# Altium schematic sheet 1_MCU.SchDoc
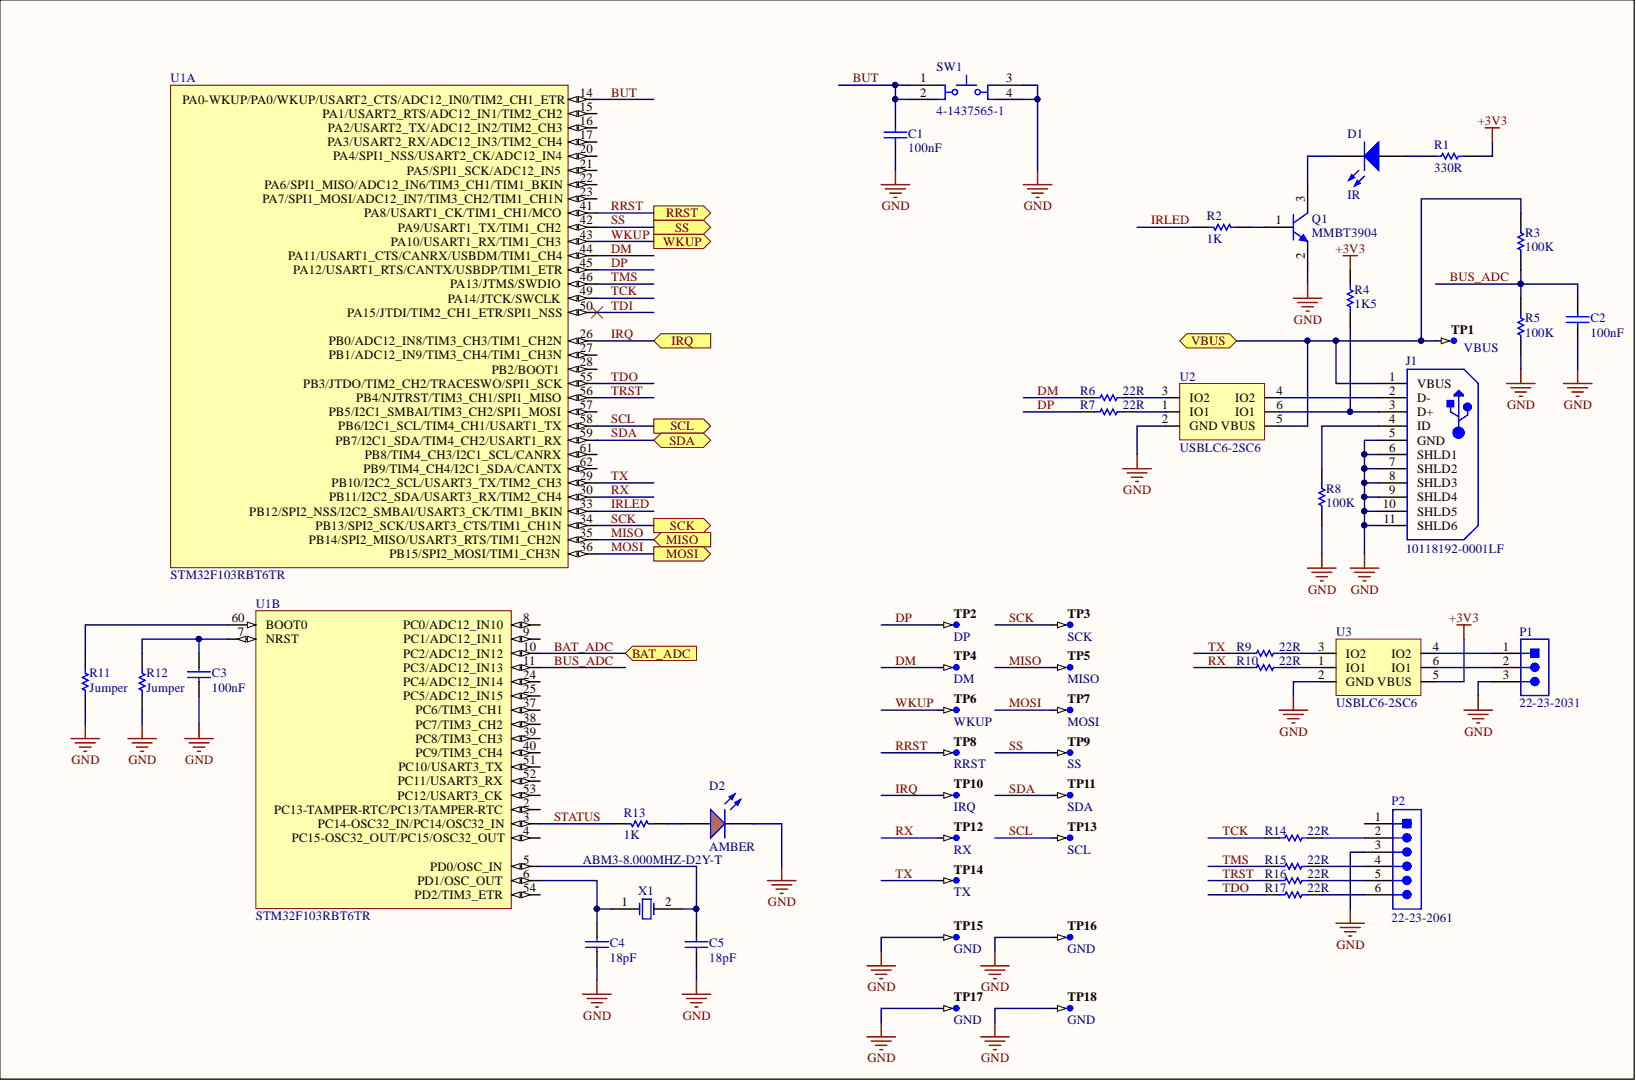
[diagram p0: 1_MCU.SchDoc]

## Parts
C1  100nF  [CAPC0603(1608)100_M]
C2  100nF  [CAPC0603(1608)100_M]
C3  100nF  [CAPC0603(1608)100_M]
C4  18pF  [CAPC0603(1608)100_M]
C5  18pF  [CAPC0603(1608)100_M]
D1  IR  [Type 3mm]
D2  AMBER  [LTST-C171AKT_V]
J1  10118192-0001LF  [FCI-10118192-0001LF-5_V]
P1  22-23-2031  [MOLX-22-23-2031_V]
P2  22-23-2061  [MOLX-22-23-2061_V]
Q1  MMBT3904  [FAIR-SOT23-3_V]
R1  330R  [RESC0603(1608)_M]
R2  1K  [RESC0603(1608)_M]
R3  100K  [RESC0603(1608)_M]
R4  1K5  [RESC0603(1608)_M]
R5  100K  [RESC0603(1608)_M]
R6  22R  [RESC0603(1608)_M]
R7  22R  [RESC0603(1608)_M]
R8  100K  [RESC0603(1608)_M]
R9  22R  [RESC0603(1608)_M]
R10  22R  [RESC0603(1608)_M]
R11  Jumper  [RESC0805(2012)_M]
R12  Jumper  [RESC0805(2012)_M]
R13  1K  [RESC0603(1608)_M]
R14  22R  [RESC0603(1608)_M]
R15  22R  [RESC0603(1608)_M]
R16  22R  [RESC0603(1608)_M]
R17  22R  [RESC0603(1608)_M]
SW1  4-1437565-1  [TECO-4-1437565-1_V]
TP1  VBUS  [TP-SM-C-100]  (no BOM)
TP2  DP  [TP-SM-C-100]  (no BOM)
TP3  SCK  [TP-SM-C-100]  (no BOM)
TP4  DM  [TP-SM-C-100]  (no BOM)
TP5  MISO  [TP-SM-C-100]  (no BOM)
TP6  WKUP  [TP-SM-C-100]  (no BOM)
TP7  MOSI  [TP-SM-C-100]  (no BOM)
TP8  RRST  [TP-SM-C-100]  (no BOM)
TP9  SS  [TP-SM-C-100]  (no BOM)
TP10  IRQ  [TP-SM-C-100]  (no BOM)
TP11  SDA  [TP-SM-C-100]  (no BOM)
TP12  RX  [TP-SM-C-100]  (no BOM)
TP13  SCL  [TP-SM-C-100]  (no BOM)
TP14  TX  [TP-SM-C-100]  (no BOM)
TP15  GND  [TP-SM-C-100]  (no BOM)
TP16  GND  [TP-SM-C-100]  (no BOM)
TP17  GND  [TP-SM-C-100]  (no BOM)
TP18  GND  [TP-SM-C-100]  (no BOM)
U1  STM32F103RBT6TR  [STM-LQFP64_N]  (parts A,B)
U2  USBLC6-2SC6  [SOT95P280X145-6N]
U3  USBLC6-2SC6  [SOT95P280X145-6N]
X1  ABM3-8.000MHZ-D2Y-T  [ABRA-ABM3-2_V]

## Ports
BAT_ADC, IRQ, MISO, MOSI, RRST, SCK, SCL, SDA, SS, VBUS, WKUP

## Nets
+3V3: R1.2 R4.2 U3.5(VBUS)
GND: C1.2 C2.2 C3.2 C4.1 C5.1 D2.C J1.5(GND) J1.6(SHLD1) J1.7(SHLD2) J1.8(SHLD3) J1.9(SHLD4) J1.10(SHLD5) J1.11(SHLD6) P1.3 P2.3 Q1.2 R5.1 R8.1 R11.1 R12.1 SW1.3 SW1.4 TP15.1 TP16.1 TP17.1 TP18.1 U2.2(GND) U3.2(GND)
BAT_ADC: U1.10(PC2/ADC12_IN12) ; port BAT_ADC
BUS_ADC: C2.1 R3.1 R5.2 U1.11(PC3/ADC12_IN13)
BUT: C1.1 SW1.1 SW1.2 U1.14(PA0-WKUP/PA0/WKUP/USART2_CTS/ADC12_IN0/TIM2_CH1_ETR)
DM: R6.1 TP4.1 U1.44(PA11/USART1_CTS/CANRX/USBDM/TIM1_CH4)
DP: R7.1 TP2.1 U1.45(PA12/USART1_RTS/CANTX/USBDP/TIM1_ETR)
IRLED: R2.2 U1.33(PB12/SPI2_NSS/I2C2_SMBAl/USART3_CK/TIM1_BKIN)
IRQ: TP10.1 U1.26(PB0/ADC12_IN8/TIM3_CH3/TIM1_CH2N) ; port IRQ
MISO: TP5.1 U1.35(PB14/SPI2_MISO/USART3_RTS/TIM1_CH2N) ; port MISO
MOSI: TP7.1 U1.36(PB15/SPI2_MOSI/TIM1_CH3N) ; port MOSI
RRST: TP8.1 U1.41(PA8/USART1_CK/TIM1_CH1/MCO) ; port RRST
RX: R10.1 TP12.1 U1.30(PB11/I2C2_SDA/USART3_RX/TIM2_CH4)
SCK: TP3.1 U1.34(PB13/SPI2_SCK/USART3_CTS/TIM1_CH1N) ; port SCK
SCL: TP13.1 U1.58(PB6/I2C1_SCL/TIM4_CH1/USART1_TX) ; port SCL
SDA: TP11.1 U1.59(PB7/I2C1_SDA/TIM4_CH2/USART1_RX) ; port SDA
SS: TP9.1 U1.42(PA9/USART1_TX/TIM1_CH2) ; port SS
STATUS: R13.2 U1.3(PC14-OSC32_IN/PC14/OSC32_IN)
TCK: R14.1 U1.49(PA14/JTCK/SWCLK)
TDI: U1.50(PA15/JTDI/TIM2_CH1_ETR/SPI1_NSS)
TDO: R17.1 U1.55(PB3/JTDO/TIM2_CH2/TRACESWO/SPI1_SCK)
TMS: R15.1 U1.46(PA13/JTMS/SWDIO)
TRST: R16.1 U1.56(PB4/NJTRST/TIM3_CH1/SPI1_MISO)
TX: R9.1 TP14.1 U1.29(PB10/I2C2_SCL/USART3_TX/TIM2_CH3)
VBUS: J1.1(VBUS) R3.2 TP1.1 U2.5(VBUS) ; port VBUS
WKUP: TP6.1 U1.43(PA10/USART1_RX/TIM1_CH3) ; port WKUP
NetC3_1: C3.1 R12.2 U1.7(NRST)
NetC4_2: C4.2 U1.6(PD1/OSC_OUT) X1.1
NetC5_2: C5.2 U1.5(PD0/OSC_IN) X1.2
NetD1_1: D1.1 Q1.3
NetD1_2: D1.2 R1.1
NetD2_A: D2.A R13.1
NetJ1_2: J1.2(D-) U2.4(IO2)
NetJ1_3: J1.3(D+) R4.1 U2.6(IO1)
NetJ1_4: J1.4(ID) R8.2
NetP1_1: P1.1 U3.4(IO2)
NetP1_2: P1.2 U3.6(IO1)
NetP2_2: P2.2 R14.2
NetP2_4: P2.4 R15.2
NetP2_5: P2.5 R16.2
NetP2_6: P2.6 R17.2
NetQ1_1: Q1.1 R2.1
NetR6_2: R6.2 U2.3(IO2)
NetR7_2: R7.2 U2.1(IO1)
NetR9_2: R9.2 U3.3(IO2)
NetR10_2: R10.2 U3.1(IO1)
NetR11_2: R11.2 U1.60(BOOT0)

Not connected: P2 1; U1 2(PC13-TAMPER-RTC/PC13/TAMPER-RTC) 4(PC15-OSC32_OUT/PC15/OSC32_OUT) 8(PC0/ADC12_IN10) 9(PC1/ADC12_IN11) 15(PA1/USART2_RTS/ADC12_IN1/TIM2_CH2) 16(PA2/USART2_TX/ADC12_IN2/TIM2_CH3) 17(PA3/USART2_RX/ADC12_IN3/TIM2_CH4) 20(PA4/SPI1_NSS/USART2_CK/ADC12_IN4) 21(PA5/SPI1_SCK/ADC12_IN5) 22(PA6/SPI1_MISO/ADC12_IN6/TIM3_CH1/TIM1_BKIN) 23(PA7/SPI1_MOSI/ADC12_IN7/TIM3_CH2/TIM1_CH1N) 24(PC4/ADC12_IN14) 25(PC5/ADC12_IN15) 27(PB1/ADC12_IN9/TIM3_CH4/TIM1_CH3N) 28(PB2/BOOT1) 37(PC6/TIM3_CH1) 38(PC7/TIM3_CH2) 39(PC8/TIM3_CH3) 40(PC9/TIM3_CH4) 51(PC10/USART3_TX) 52(PC11/USART3_RX) 53(PC12/USART3_CK) 54(PD2/TIM3_ETR) 57(PB5/I2C1_SMBAI/TIM3_CH2/SPI1_MOSI) 61(PB8/TIM4_CH3/I2C1_SCL/CANRX) 62(PB9/TIM4_CH4/I2C1_SDA/CANTX)

## Library data of the parts
C1-C3 = CMP-1035-02589-1: CAP 100nF 25V ±20% 0603 (1608 Metric) Thickness 1mm SMD; Case-EIA: 0603; Case-Metric: 1608; Tolerance: Â±20%; Component Kind: Standard; Library Name: Altium Content Vault; Max Thickness: 1 mm; Rated Voltage: 25 V; Technology: SMT; Value: 100nF
C4 C5 = CMP-1035-00741-1: CAP 18pF 25V ±0.5pF 0603 (1608 Metric) Thickness 1mm SMD; Case-EIA: 0603; Case-Metric: 1608; Tolerance: Â±0.5pF; Component Kind: Standard; Library Name: Altium Content Vault; Max Thickness: 1 mm; Rated Voltage: 25 V; Technology: SMT; Value: 18pF
D1 = CMP-1488-00005-1: THT LED round mono-color color lens, WL-TMRW, Super Red; Manufacturer: Wuerth Elektronik; PartNumber: 151031SS04000; Component Kind: Standard; ComponentLink1Description: Manufacturer URL; ComponentLink1URL: http://www.we-online.com; ComponentLink2Description: Datasheet; ComponentLink2URL: http://katalog.we-online.de/pbs/datasheet/7448042001.pdfhttp://katalog.we-online.de/pbs/datasheet/7448042001.pdfhttp://katalog.we-online.de/pbs/datasheet/7448042001.pdfhttp://katalog.we-online.de/pbs/datasheet/151031SS04000.pdf; Emitting color: Super Red; Library Name: Altium Content Vault; PackageReference: Type 3mm
D2 = CMP-1674-00004-3: LED AMBER CLR THIN 0805 SMD; Manufacturer: Lite-On; PartNumber: LTST-C171AKT; Component Kind: Standard; ComponentLink1Description: Datasheet; ComponentLink1URL: http://optoelectronics.liteon.com/upload/download/DS-22-99-0170/S_110_LTST-C171AKT.pdf; Library Name: Altium Content Vault; PackageReference: C171AKT
J1 = CMP-2000-05118-1: Micro USB B Type Receptacle, -55 to 85 degC, 5-Pin SMD, RoHS, Tape and Reel; Brand: FCI; Connector Type: MicroUSBTypeBreceptacle; Current Rating: 1.8A; Gender: Female; Insulation Resistance: 1000MOhms; Mounting Angle: Right; Number of Contacts: 5Contact; Color: Black; Component Kind: Standard; Contact Material: CopperAlloy; Contact Plating: Gold; Datasheet URL: http://www.mouser.com/ds/2/154/10118192-793027.pdf; Datasheet Version: Rev. B, 05/2015; Factory Pack Quantity: 1400; Flammability Rating: UL94V-0; Library Name: Altium Content Vault; Manufacturer: FCI; Manufacturer URL: http://www.fci.com/; Operating Temperature Range: -55Cto+85C; Packaging: Reel; Product: MicroUSBTypeBConnectors; Product Category: USBConnectors; RoHS: Details; Standard: USB2.0; Termination Style: SMD/SMT
P1 = CMP-2000-05242-1: Male Header, Pitch 2.54 mm, 1 x 3 Position, Height 8.18 mm, Tail Length 3.56 mm, RoHS, Bulk; Brand: Molex; Current Rating: 4A; Mounting Angle: Vertical; Pitch: 2.54mm; Voltage Rating: 250V; : 0022232031A-6373-03A102; Component Kind: Standard; Contact Gender: Pin(Male); Contact Material: Brass; Contact Plating: Tin; Datasheet URL: https://datasheet.ciiva.com/19472/232274-19472863.pdf; Datasheet Version: Sep-01; Factory Pack Quantity: 16000; Housing Material: Nylon; Library Name: Altium Content Vault; Manufacturer: Molex; Manufacturer URL: http://www.molex.com/; Mounting Style: ThroughHole; Number of Positions: 3Position; Number of Rows: 1Row; Packaging: Bulk; Product: Headers; Product Category: Headers&WireHousings; RoHS: Details; Series: 6373; Termination Style: ThroughHole; Tradename: KK; Type: Locking
P2 = CMP-2000-05536-1: Male Header, Pitch 2.54 mm, 1 x 6 Position, Height 10.67 mm, Tail Length 3.56 mm, 0 to 70 degC, RoHS, Bulk; Brand: Molex; Current Rating: 4A; Mounting Angle: Vertical; Number of Positions: 6; Pitch: 2.54mm; Voltage Rating: 250V; : 002223206122-23-2061-PBA-6373-06A102; Component Kind: Standard; Contact Material: Brass; Contact Plating: Tin; Datasheet URL: https://datasheet.ciiva.com/19460/232303-19460895.pdf; Datasheet Version: Rev. P, 09/2001; Factory Pack Quantity: 1000; Housing Material: Nylon; Library Name: Altium Content Vault; Manufacturer: Molex; Manufacturer URL: http://www.molex.com/; Mounting Style: ThroughHole; Mounting Technology: ThroughHole; Number of Positions / Contacts: 6; Number of Rows: 1; Package Description: Male Header, Pitch 2.54 mm, 1 x 6 Position, Height 10.67 mm, Tail Length 3.56 mm; Package Reference: 22-23-2061; Packaging: Bulk; Product Category: .100K.K.Connectors; Product Type: Headers; RoHS: Details; Series: 6373; Termination Style: ThroughHole; Tradename: KK; Type: PinStrip
Q1 = CMP-1670-00008-1: NPN General Purpose Amplifier, 40 V, 0.2 A, -55 to 150 degC, 3-Pin SOT23, RoHS, Tape and Reel; Capacitance: 1.8E-11 f; ESR: 40 Ohm; Frequency: 12.288 MHz; Frequency Tolerance: 30ppm; Load Capacitance: 18 pF; Component Kind: Standard; ComponentLink1Description: Manufacturer URL; ComponentLink1URL: https://www.fairchildsemi.com/; ComponentLink2Description: Datasheet; ComponentLink2URL: https://www.fairchildsemi.com/datasheets/MM/MMBT3904.pdf; ComponentLink3Description: Package Specification; ComponentLink3URL: http://www.fairchildsemi.com/dwg/MA/MA03D.pdf; DatasheetVersion: Rev. 1.1.0, 10/2014; Height: 0.041 inch; Length: 0.197 inch; Library Name: Altium Content Vault; Max Operating Temperature: 70degC; Min Operating Temperature: -20degC; Mount: Surface Mount; PackageDescription: 3-Pin Small Outline Transistor, Body 2.92 x 1.325 mm, Pitch 0.95 mm; Package Quantity: 1000; PackageReference: SOT23-3; Packaging: Tape and Reel; Pins: 4; REACH SVHC: No SVHC; RoHSCompliant: True; Tolerance: 30ppm; Width: 0.126 inch
R1 = CMP-1012-00454-1: 330R 0.1W 1% 0603 (1608 Metric)  SMD; Case-EIA: 0603; Case-Metric: 1608; Value: 330.00 Ohm; Component Kind: Standard; Library Name: Altium Content Vault; Power: 0.1 W; Technology: SMT; Tolerance: 1 %
R2 R13 = CMP-1012-00510-1: 1K 0.1W 1% 0603 (1608 Metric)  SMD; Case-EIA: 0603; Case-Metric: 1608; Value: 1000.00 Ohm; Component Kind: Standard; Library Name: Altium Content Vault; Power: 0.1 W; Technology: SMT; Tolerance: 1 %
R3 R5 R8 = CMP-1012-00736-1: 100K 0.1W 1% 0603 (1608 Metric)  SMD; Case-EIA: 0603; Case-Metric: 1608; Value: 100000.00 Ohm; Component Kind: Standard; Library Name: Altium Content Vault; Power: 0.1 W; Technology: SMT; Tolerance: 1 %
R4 = CMP-1012-00528-1: 1K5 0.1W 1% 0603 (1608 Metric)  SMD; Case-EIA: 0603; Case-Metric: 1608; Value: 1500.00 Ohm; Component Kind: Standard; Library Name: Altium Content Vault; Power: 0.1 W; Technology: SMT; Tolerance: 1 %
R6 R7 R9 R10 R14-R17 = CMP-1012-00320-1: 22R 0.1W 1% 0603 (1608 Metric)  SMD; Case-EIA: 0603; Case-Metric: 1608; Value: 22.00 Ohm; Component Kind: Standard; Library Name: Altium Content Vault; Power: 0.1 W; Technology: SMT; Tolerance: 1 %
R11 R12 = CMP-1013-00001-1: Jumper 0805 (2012 Metric); Case-EIA: 0805; Case-Metric: 2012; Power: None; Value: 0.00 Ohm; Component Kind: Standard; Library Name: Altium Content Vault; Technology: SMT; Tolerance: None
SW1 = CMP-2000-05602-1: Tactile Switch, 50 mA, 12 VDC, -20 to 85 degC, 4-Pin SMD, RoHS, Bulk; Manufacturer: TEConnectivity/AMPBrand; Actuator Length: 1.4mm; Actuator Style: RoundButton; Body Orientation: Straight; Contact Rating @ Voltage: 0.05@12VDCA; Dielectric Strength: 500VAC; Electrical Life: 100000Cycle; Ground Terminal: No; Illumination Type: N/R; Maximum Contact Resistance: 100mOhm; Maximum DC Voltage Rating: 12V; Operating Force: 1.57N; Actuator Color: N/A; Base Material: N/A; Component Kind: Standard; Contact Material: CopperAlloy; Contact Plating: Silver; Datasheet URL: https://datasheet.ciiva.com/14635/0900766b80912216-14635826.pdf; Datasheet Version: 04/2007; Dimension: 6x10x5.25mm; Family: FSMJSMA; Housing Material: N/A; Library Name: Altium Content Vault; Manufacturer URL: http://www.te.com/; Maximum AC Voltage Rating: N/A; Mechanical Life: N/A; Minimum Insulation Resistance: 100MOhm; Mounting: SurfaceMount,GullWing; Mounting Technology: SurfaceMount; Operating Temperature: -20to85C; Package Description: 4-Pin Surface Mount Device, Body 6 x 6 mm, Pitch 9.1 mm; Package Reference: 4-1437565-1; Packaging: Bulk; Part No: 4-1437565-1; Pole Throw Configuration: N/A; Product Weight: N/A; RoHS: Yes; Seal Description: N/A; Seal Location: N/A; Switch Configuration: N/A; Type: N/A
TP1-TP18 = CMP-0484-00002-2: Test Point, Surface Mount, Circular, Pad Diameter 1 mm; Manufacturer: No Vendor; Library Name: Altium Content Vault; PartNumber: TP-SM-C-100
U1 = CMP-0237-00169-2: ARM Cortex-M3 32-bit MCU, 128 KB Flash, 20 KB Internal RAM, 51 I/Os, 64-pin LQFP, -40 to 85 degC, Tape and Reel; A/D Converter: 2x12-bit (16 Channel); FLASH Size (Prog) (kB): 128; I/Os (High Current): 51; Internal RAM Size (kB): 20; Operating Frequency(F) (Processor speed) (MHz): 72; Supply Voltage(Vcc) min (V): 2; Component Kind: Standard; ComponentLink1Description: Manufacturer URL; ComponentLink1URL: http://www.st.com/web/en/home.html; ComponentLink2Description: Datasheet; ComponentLink2URL: http://www.st.com/st-web-ui/static/active/en/resource/technical/document/datasheet/CD00161566.pdf; ComponentLink3Description: Package Specification; ComponentLink3URL: http://www.st.com/st-web-ui/static/active/en/resource/technical/document/datasheet/DM00037051.pdf; Core: ARM Cortex-M3; DatasheetVersion: Rev. 16; Library Name: Altium Content Vault; Mounting Technology: Surface Mount; PackageDescription: 64-Pin Low Profile Quad Flat Package, 10 x 10 mm Body, 0.5 mm Pitch; PackageReference: LQFP64; PackageVersion: Rev. 4, 06/2013; Packing: Tape and Reel; PartId: 1; PartNumber: STM32F103RBT6TR
U2 U3 = USBLC6-2SC6: TVS DIODE 5.25V 17V SOT23-6; Manufacturer: STMicroelectronics; Breakdown Voltage: 6V; Capacitance: 3.5pF; Data Rate: 480Mbps; Direction: Unidirectional; Element Configuration: Dual; ESD Protection: Yes; Leakage Current: 150nA; Number of Bidirectional Channels: 2; Number of Elements: 1; Operating Supply Voltage: 1.1V; Peak Pulse Current: 5A; Test Current: 1mA; Working Voltage: 5V; Case/Package: SOT-23; Clamping Voltage: 17V; Component Kind: Standard; ComponentLink1Description: https://datasheet.ciiva.com/1268/1690354-1268384.pdf?src-supplier=Element14; ComponentLink1URL: https://datasheet.ciiva.com/1268/1690354-1268384.pdf?src-supplier=Element14; ComponentLink2Description: https://datasheet.ciiva.com/4508/cd00050750-113117-4508433.pdf?src-supplier=Mouser; ComponentLink2URL: https://datasheet.ciiva.com/4508/cd00050750-113117-4508433.pdf?src-supplier=Mouser; ComponentLink3Description: https://datasheet.ciiva.com/15143/getdatasheetpartid-148465-15143789.pdf?src-supplier=Verical; ComponentLink3URL: https://datasheet.ciiva.com/15143/getdatasheetpartid-148465-15143789.pdf?src-supplier=Verical; ComponentLink4Description: https://datasheet.ciiva.com/26787/usblc6-2sc6-26787908.pdf?src-supplier=IIIC; ComponentLink4URL: https://datasheet.ciiva.com/26787/usblc6-2sc6-26787908.pdf?src-supplier=IIIC; ComponentLink5Description: https://datasheet.ciiva.com/16200/cd00050750-16200026.pdf?src-supplier=Future+Electronics; ComponentLink5URL: https://datasheet.ciiva.com/16200/cd00050750-16200026.pdf?src-supplier=Future+Electronics; ComponentLink6Description: https://datasheet.ciiva.com/41444/001184109-da-01-en-ic-esd-protecti-usblc6-2sc6-sot-23-6-stm-41444156.pdf?src-supplier=Conrad; ComponentLink6URL: https://datasheet.ciiva.com/41444/001184109-da-01-en-ic-esd-protecti-usblc6-2sc6-sot-23-6-stm-41444156.pdf?src-supplier=Conrad; Contact Plating: Tin; Depth: 1.75mm; Height: 1.3mm; Lead Free: Lead Free; Length: 3.05mm; Manufacturer Part Number: USBLC6-2SC6; Max Breakdown Voltage: 6V; Max Operating Temperature: 125°C; Max Reverse Leakage Current: 150nA; Min Breakdown Voltage: 6V; Min Operating Temperature: -40°C; Mount: Surface Mount; Number of Channels: 2; Number of Pins: 6; Package Quantity: 3000; Packaging: Tape and Reel; Polarity: Unidirectional; Power Line Protection: Yes; Pricing 1: 1 = 0,49000, 10 = 0,41900, 100 = 0,31310, 500 = 0,24602, 1000 = 0,19010 (USD); Radiation Hardening: No; REACH SVHC: No SVHC; Reverse Breakdown Voltage: 6V; Reverse Standoff Voltage: 5.25V; RoHS: Yes; Stock 1: 85571; Supplier 1: Digi-Key; Termination: SMD/SMT; Width: 1.75mm; Library Name: PositionMiniModule.SchLib
X1 = CMP-2000-05033-1: Miniature Ceramic Crystal, 8 MHz, -40 to 85 degC, 2-Pin SMD, RoHS, Tape and Reel; Brand: ABRACON; Case Height: 1.3mm; Case Length: 5mm; Case Width: 3.2mm; ESR: 140Ohms; Frequency: 8MHz; Frequency Stability: 30PPM; Load Capacitance: 18pF; Tolerance: 20PPM; Component Kind: Standard; Datasheet URL: http://www.farnell.com/datasheets/1754353.pdf; Datasheet Version: Sep-13; Dimensions: 3.2mmWx5mmLx1.3mmH; Factory Pack Quantity: 1000; Library Name: Altium Content Vault; Manufacturer: ABRACON; Manufacturer URL: http://www.abracon.com/; Mounting Style: SMD/SMT; Operating Temperature Range: -40Cto+85C; Package / Case: 5mmx3.2mm; Package Description: 2-Pin Surface Mount Device, Body 5 x 3.2 mm; Package Reference: ABM3-2; Package URL: http://www.abracon.com/Support/PackageDrawing/Resonators/ABM3.PDF; Packaging: Reel; Product Category: Crystals; RoHS: Details; Series: ABM3; Termination Style: SMD/SMT; Type: MiniatureCeramicCrystal
